# Altium schematic sheet MCU.SchDoc
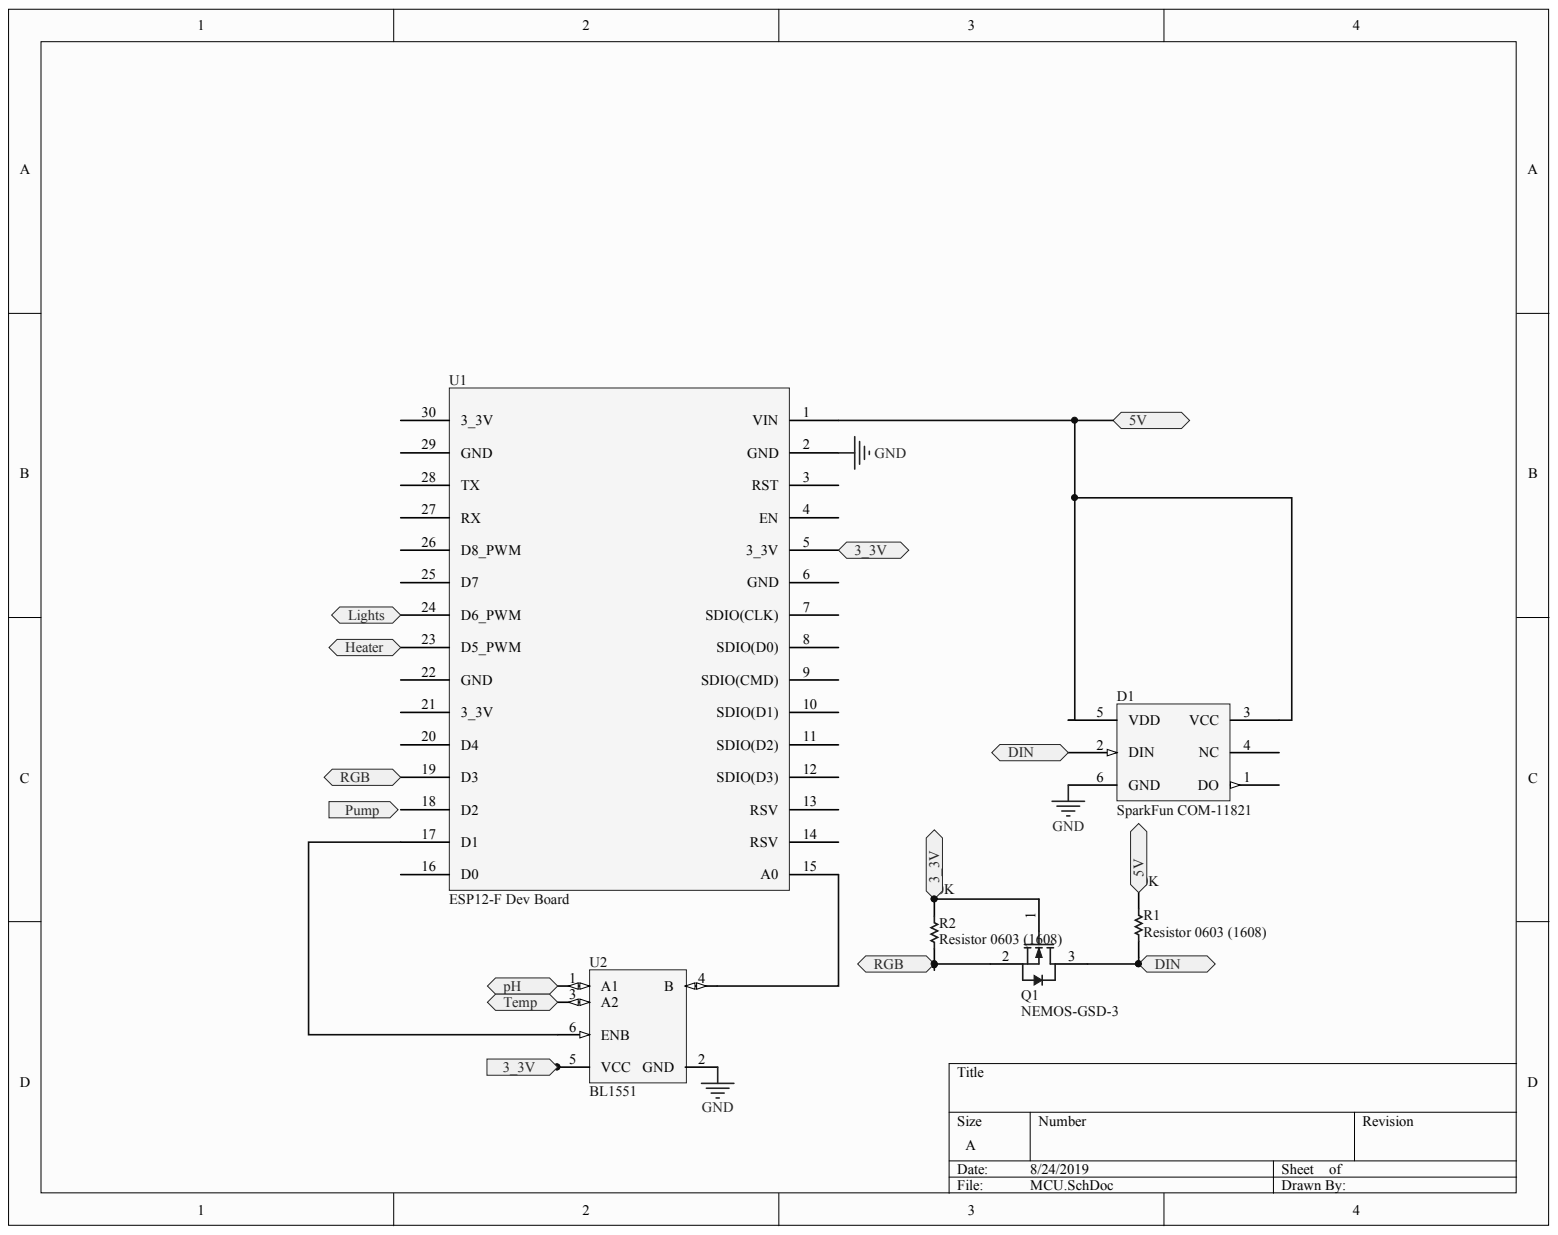
[diagram p0: MCU.SchDoc]

## Parts
D1  SparkFun COM-11821  [PCB-1ur4ffa4apwrigk38oa4-1]
Q1  NEMOS-GSD-3  [PCB-dbhk9q13wds7exg38ggf-1]
R1  Resistor 0603 (1608)  Resistance: 10K  [PCB-8xtmhdk62wywm3ayihyv-1]
R2  Resistor 0603 (1608)  Resistance: 10K  [PCB-8xtmhdk62wywm3ayihyv-1]
U1  ESP12-F Dev Board  [PCB-z8aaw0yugxv87lcq77q0-1]
U2  BL1551  [SC70]

## Ports
3_3V, 5V, DIN, HEATER, LIGHTS, PH, PUMP, RGB, TEMP

## Nets
GND: D1.6(GND) U1.2(GND) U2.2(GND)
3_3V: Q1.1 R2.2 U1.5(3_3V) U2.5(VCC) ; port 3_3V
5V: D1.3(VCC) D1.5(VDD) R1.2 U1.1(VIN) ; port 5V
DIN: D1.2(DIN) Q1.3 R1.1 ; port DIN
RGB: Q1.2 R2.1 U1.19(D3) ; port RGB
NetU1_15: U1.15(A0) U2.4(B)
NetU1_17: U1.17(D1) U2.6(ENB)
NetU1_23: U1.23(D5_PWM) ; port HEATER
NetU1_24: U1.24(D6_PWM) ; port LIGHTS
NetU2_1: U2.1(A1) ; port PH
NetU2_3: U2.3(A2) ; port TEMP
N00023: ; port PUMP

Not connected: D1 1(DO) 4(NC); U1 3(RST) 4(EN) 6(GND) 7(SDIO(CLK)) 8(SDIO(D0)) 9(SDIO(CMD)) 10(SDIO(D1)) 11(SDIO(D2)) 12(SDIO(D3)) 13(RSV) 14(RSV) 16(D0) 18(D2) 20(D4) 21(3_3V) 22(GND) 25(D7) 26(D8_PWM) 27(RX) 28(TX) 29(GND) 30(3_3V)

## Library data of the parts
D1 = CMP-ba0777713b8065c0-3: Led - Smd Rgb (Ws2812); Component Kind: Standard; Library Name: CommunityVault; OctopartID: ba0777713b8065c0
Q1 = CMP-2868e1aa5c2af7af-8: Transistor NPN Mos BSS138 FAIRCHILD RoHS miliampere=220 V=50 SOt23; Manufacturer: Fairchild Semiconductor; Breakdown Voltage (Drain to Source): 50.0 V; Breakdown Voltage [Gate to Source]: -20.0 V to 20.0 V; Continuous Drain Current (Ids): 220 mA; Drain to Source Resistance (on) (Rds): 3.50 Ω; Input Capacitance: 27.0 pF; Lead-Free Status: Lead Free; Polarity: N-Channel; Case/Package: SOT-23; Component Kind: Standard; Library Name: CommunityVault; Lifecycle Status: Active; Manufacturer Part Number: BSS138; Number of Pins: 3; Octopart URL: http://octopart.com/bss138-fairchild+semiconductor-13127972; Packaging: Cut Tape (CT), Tape & Reel (TR); Voltage Rating (DC): 50.0 V
R1 R2 = CMP-8d0d5kw4b9o869c0if8y-1: Generic Resistor pad 0603 Imperial and 1608 Metric; Case/Package: 0603 (1608); Component Kind: Standard; CustomComponentID: 8d0d5kw4b9o869c0if8y; Library Name: CommunityVault
U1 = CMP-sxw3ufcwmxs35hbw3bea-7: Board for the ESP12-F module made by Doit.am; Component Kind: Standard; CustomComponentID: sxw3ufcwmxs35hbw3bea; Homepage URL: https://github.com/SmartArduino/SZDOITWiKi/wiki/ESP8266---ESP-F; Library Name: FavoritesLibrary; Manufacturer: Doit.am
U2 = CMP-42bc8bb153389d7b-2: Analog switch; Channels: 1; SC70; Contacts Type: SPDT; Number of Bits: 1; Supply Voltage (DC): 1.65 V to 5.50 V; Case/Package: SC70; Component Kind: Standard; Library Name: CommunityVault; Manufacturer Part Number: BL1551; Mounting Style: Surface Mount; Number of Channels: 1; Number of Pins: 6; OctopartID: 42bc8bb153389d7b; Packaging: Tape & Reel (TR), Cut Tape (CT)
